# Altium schematic sheet SCH_Mauri_2_Octave_Bass_Boost.SchDoc(SCH_Mauri_2_Octave_Bass_Boost)
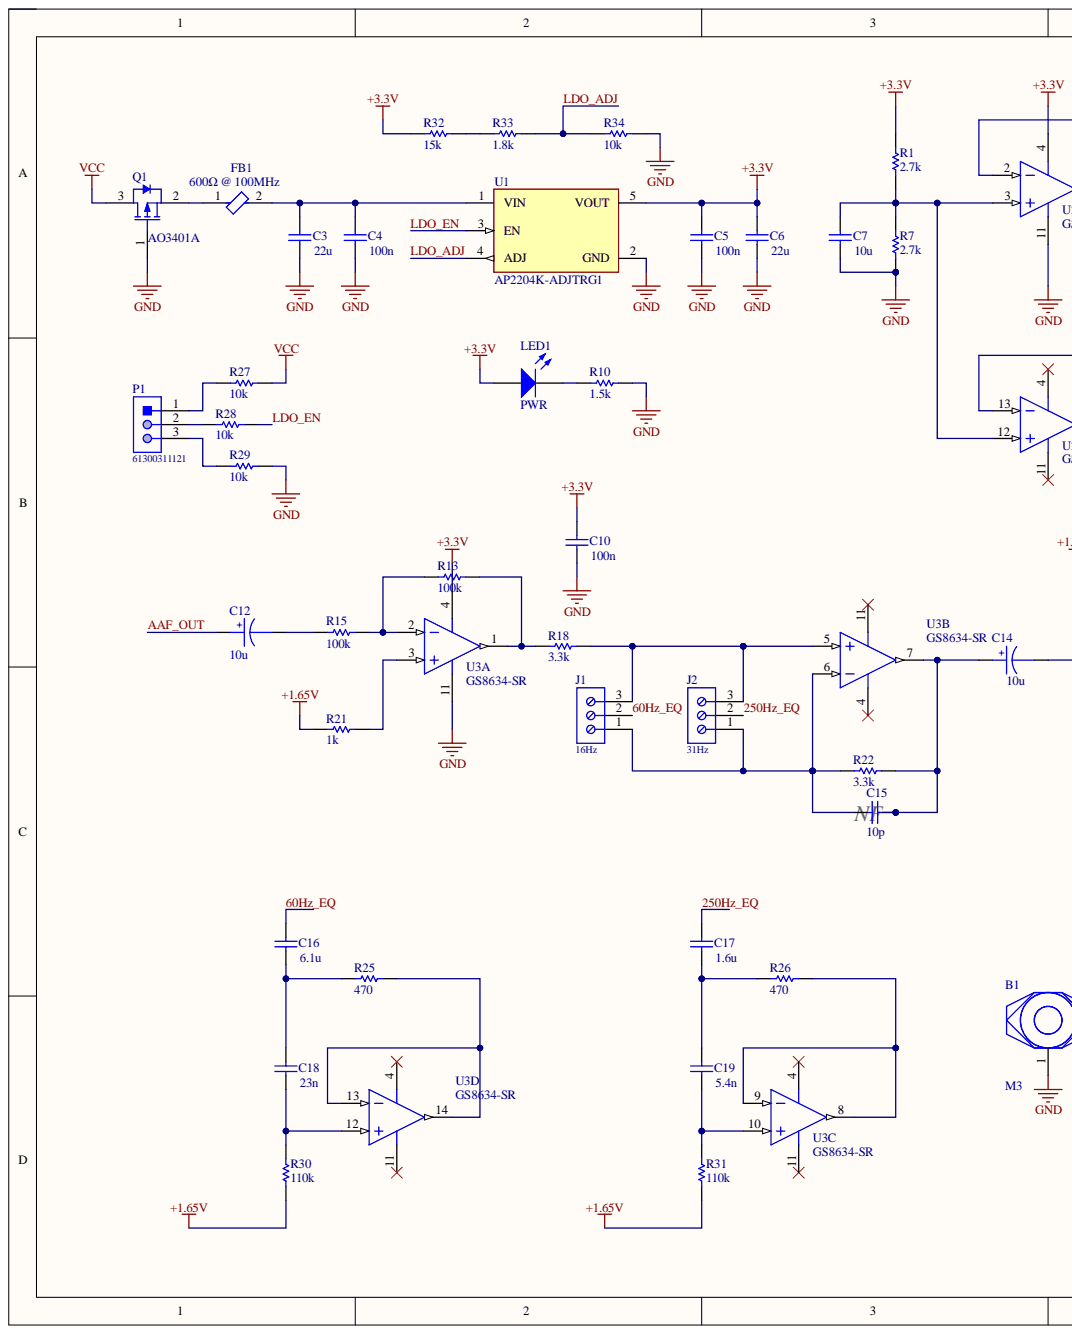
[diagram p0: SCH_Mauri_2_Octave_Bass_Boost.SchDoc, left]
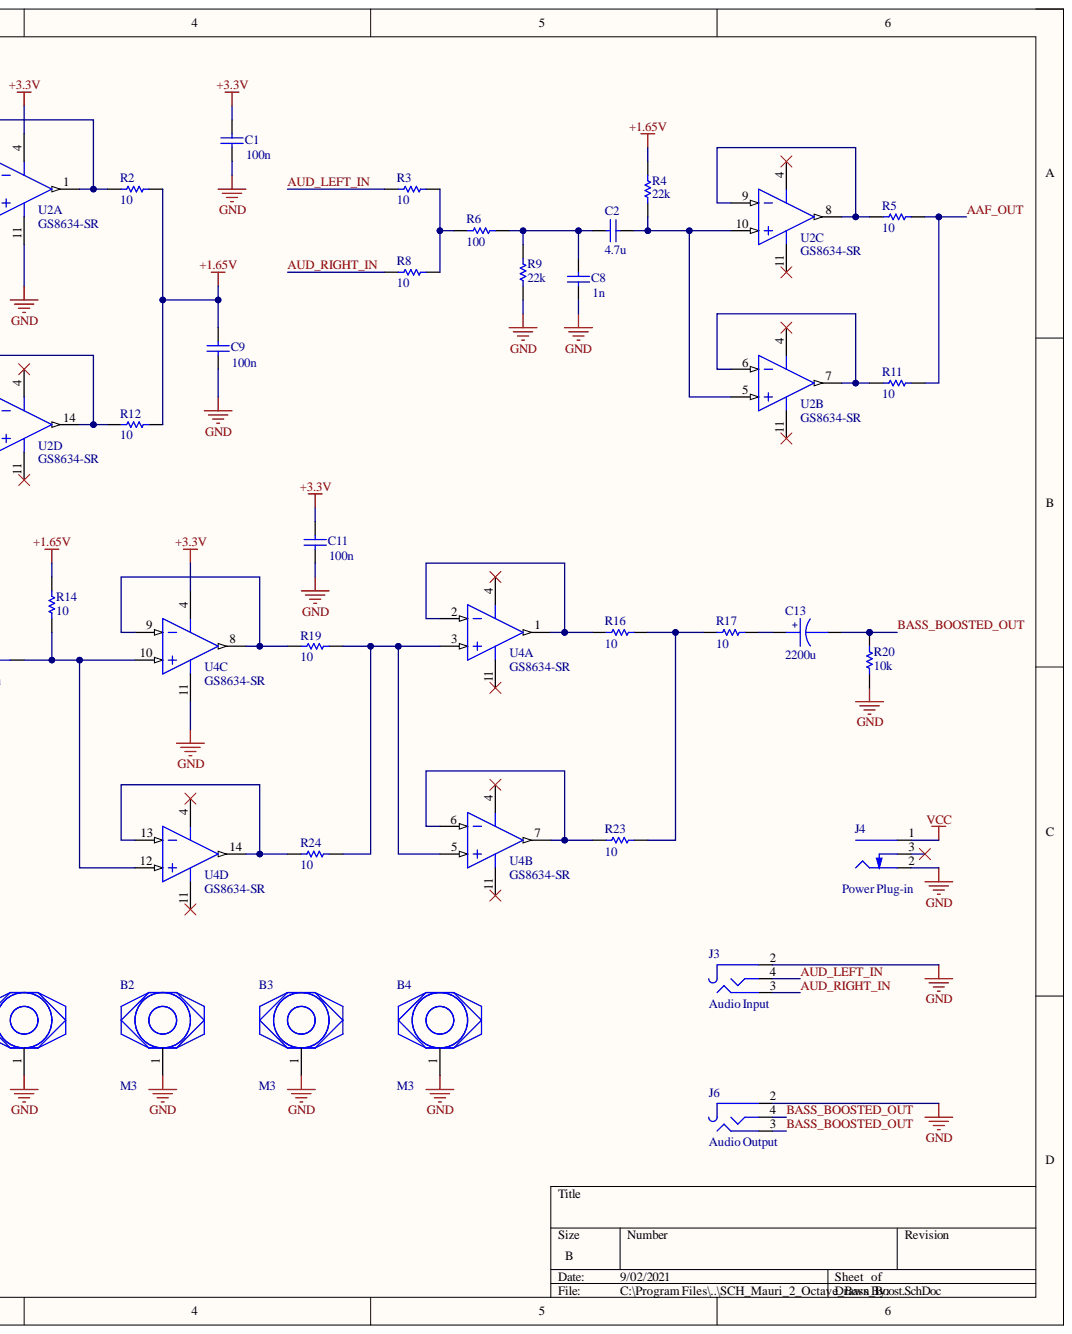
[diagram p1: SCH_Mauri_2_Octave_Bass_Boost.SchDoc, right]

## Parts
B1  M3  [BOLTM3 - with vias]
B2  M3  [BOLTM3 - with vias]
B3  M3  [BOLTM3 - with vias]
B4  M3  [BOLTM3 - with vias]
C1  100n  [CAPC2013X95X50NL10T25]
C2  4.7u  [CAPC2013X95X50NL10T25]
C3  22u  [CAPC2013X95X50NL10T25]
C4  100n  [CAPC2013X95X50NL10T25]
C5  100n  [CAPC2013X95X50NL10T25]
C6  22u  [CAPC2013X95X50NL10T25]
C7  10u  [CAPC2013X95X50NL10T25]
C8  1n  [CAPC2013X95X50NL10T25]
C9  100n  [CAPC2013X95X50NL10T25]
C10  100n  [CAPC2013X95X50NL10T25]
C11  100n  [CAPC2013X95X50NL10T25]
C12  10u  [RB.1/.2/.4]
C13  2200u  [RB.1/.2/.4]
C14  10u  [RB.1/.2/.4]
C15  10p  [CAPC2013X95X50NL10T25]
C16  6.1u  [CAPC2013X95X50NL10T25]
C17  1.6u  [CAPC2013X95X50NL10T25]
C18  23n  [CAPC2013X95X50NL10T25]
C19  5.4n  [CAPC2013X95X50NL10T25]
FB1  value 600Ω @ 100MHz  Impedance At Frequency: 600Ω @ 100MHz  Test Frequency: 100MHz  [FP-ILHB-0805-IPC_A]  (hidden comment: GZ2012D601TF)
J1  16Hz  [691213710003]
J2  31Hz  [691213710003]
J3  Audio Input  [AUDIO-TH_PJ313D]
J4  Power Plug-in  [CUI PJ-102AH]
J6  Audio Output  [AUDIO-TH_PJ313D]
LED1  PWR  [0805_A]
P1  61300311121  [61300311121]
Q1  AO3401A  [AO-SOT-23-3L_V]
R1  2.7k  [RESC2013X70X40NL20T20]
R2  10  [RESC2013X70X40NL20T20]
R3  10  [RESC2013X70X40NL20T20]
R4  22k  [RESC2013X70X40NL20T20]
R5  10  [RESC2013X70X40NL20T20]
R6  100  [RESC2013X70X40NL20T20]
R7  2.7k  [RESC2013X70X40NL20T20]
R8  10  [RESC2013X70X40NL20T20]
R9  22k  [RESC2013X70X40NL20T20]
R10  1.5k  [RESC2013X70X40NL20T20]
R11  10  [RESC2013X70X40NL20T20]
R12  10  [RESC2013X70X40NL20T20]
R13  100k  [RESC2013X70X40NL20T20]
R14  10  [RESC2013X70X40NL20T20]
R15  100k  [RESC2013X70X40NL20T20]
R16  10  [RESC2013X70X40NL20T20]
R17  10  [RESC2013X70X40NL20T20]
R18  3.3k  [RESC2013X70X40NL20T20]
R19  10  [RESC2013X70X40NL20T20]
R20  10k  [RESC2013X70X40NL20T20]
R21  1k  [RESC2013X70X40NL20T20]
R22  3.3k  [RESC2013X70X40NL20T20]
R23  10  [RESC2013X70X40NL20T20]
R24  10  [RESC2013X70X40NL20T20]
R25  470  [RESC2013X70X40NL20T20]
R26  470  [RESC2013X70X40NL20T20]
R27  10k  [RESC2013X70X40NL20T20]
R28  10k  [RESC2013X70X40NL20T20]
R29  10k  [RESC2013X70X40NL20T20]
R30  110k  [RESC2013X70X40NL20T20]
R31  110k  [RESC2013X70X40NL20T20]
R32  15k  [RESC2013X70X40NL20T20]
R33  1.8k  [RESC2013X70X40NL20T20]
R34  10k  [RESC2013X70X40NL20T20]
U1  AP2204K-ADJTRG1  [FP-SOT25-MFG]
U2  GS8634-SR  [D0014A_V]  (parts A,B,C,D)
U3  GS8634-SR  [D0014A_V]  (parts A,B,C,D)
U4  GS8634-SR  [D0014A_V]  (parts A,B,C,D)

## Nets
+1.65V: C9.2 R2.2 R4.1 R12.2 R14.2 R21.1 R30.1 R31.1
+3.3V: C1.2 C5.2 C6.2 C10.2 C11.2 LED1.2 R1.1 R32.1 U1.5(VOUT) U2.4 U3.4 U4.4
GND: B1.1 B2.1 B3.1 B4.1 C1.1 C3.1 C4.1 C5.1 C6.1 C7.1 C8.1 C9.1 C10.1 C11.1 J3.2 J4.2 J6.2 Q1.1 R7.2 R9.2 R10.2 R20.1 R29.1 R34.2 U1.2(GND) U2.11 U3.11 U4.11
VCC: J4.1 Q1.3 R27.1
60Hz_EQ: C16.2 J1.2
250Hz_EQ: C17.2 J2.2
AAF_OUT: C12.1 R5.2 R11.2
AUD_LEFT_IN: J3.4 R3.2
AUD_RIGHT_IN: J3.3 R8.2
BASS_BOOSTED_OUT: C13.2 J6.3 J6.4 R20.2
LDO_ADJ: R33.2 R34.1 U1.4(ADJ)
LDO_EN: R28.1 U1.3(EN)
NetC2_1: C2.1 R4.2 U2.5 U2.10
NetC2_2: C2.2 C8.2 R6.1 R9.1
NetC3_2: C3.2 C4.2 FB1.2 U1.1(VIN)
NetC7_2: C7.2 R1.2 R7.1 U2.3 U2.12
NetC12_2: C12.2 R15.1
NetC13_1: C13.1 R17.1
NetC14_1: C14.1 C15.1 R22.2 U3.7
NetC14_2: C14.2 R14.1 U4.10 U4.12
NetC15_2: C15.2 J1.1 J2.1 R22.1 U3.6
NetC16_1: C16.1 C18.2 R25.2
NetC17_1: C17.1 C19.2 R26.2
NetC18_1: C18.1 R30.2 U3.12
NetC19_1: C19.1 R31.2 U3.10
NetFB1_1: FB1.1 Q1.2
NetJ1_3: J1.3 J2.3 R18.2 U3.5
NetLED1_1: LED1.1 R10.1
NetP1_1: P1.1 R27.2
NetP1_2: P1.2 R28.2
NetP1_3: P1.3 R29.2
NetR2_1: R2.1 U2.1 U2.2
NetR3_1: R3.1 R6.2 R8.1
NetR5_1: R5.1 U2.8 U2.9
NetR11_1: R11.1 U2.6 U2.7
NetR12_1: R12.1 U2.13 U2.14
NetR13_1: R13.1 R15.2 U3.2
NetR13_2: R13.2 R18.1 U3.1
NetR16_1: R16.1 R17.2 R23.1
NetR16_2: R16.2 U4.1 U4.2
NetR19_1: R19.1 U4.8 U4.9
NetR19_2: R19.2 R24.2 U4.3 U4.5
NetR21_2: R21.2 U3.3
NetR23_2: R23.2 U4.6 U4.7
NetR24_1: R24.1 U4.13 U4.14
NetR25_1: R25.1 U3.13 U3.14
NetR26_1: R26.1 U3.8 U3.9
NetR32_2: R32.2 R33.1

Not connected: J4 3

## Library data of the parts
B1-B4 = BOLT: M3 Mounting Bolt; Component Kind: Standard; Library Name: Altium Primer.SchLib
C1-C11 C15-C19 = CMP-001-00018-6: Capacitor Type: Ceramic; Tolerance: 10%; Value: 100nF; Voltage Rating: 50V; Case/Package: 0805; Component Kind: Standard; Max Operating Temperature: 125°C; Min Operating Temperature: -55°C; Mounting Technology: SM; Pins: 2; RoHS Compliant: True; Library Name: Minh Man Ly's Workspace
C12-C14 = CAPACITOR POL: Polarized Cap; Component Kind: Standard; Library Name: Altium Primer.SchLib
FB1 = CMP-02428-000001-1: FERRITE BEAD 600 OHM 0805 1LN; Manufacturer: Vishay; Manufacturer Part Number: ILHB0805ER601V; Rms Current: 2000mA; Series Resistance: 0.1Ω; Shielding: true; Tolerance: 25%; Automotive: No; Category: FBead; Component Kind: Standard; ComponentLink2Description: Datasheet; ComponentLink2URL: http://www.vishay.com/docs/34102/ilhb.pdf; Device Class L1: Passive Components; Device Class L2: EMI / RFI Components; Device Class L3: Ferrite Beads and Chips; Digikey Description: FERRITE BEAD 600 OHM 0805 1LN; Height: 1.1mm; Ipc Land Pattern Name: CAPC200125X90; LCSC Part #: C1017; Lead Free: Yes; Library Name: Altium Content Vault; Mouser Description: Ferrite Beads Multi-Layer High Current 600Ohm 25% 100MHz 2A 100mOhm DCR 0805 T/R; Octopart: https://octopart.com/ilhb0805er601v-vishay-39650184; Package: ILHB-0805; RoHS: TRUE; Temperature: -55°C to +125°C; Temperature Range High: +125°C; Temperature Range Low: -55°C
J1 J2 = CMP-1502-02527-1: WJ126V-5.0-3P. P=5.0mm Screw terminal RoHS; Manufacturer: Ningbo Kangnex Elec; Pin Number: 3; Pitch: 5.00mm; Component Kind: Standard; Datasheet URL: https://lcsc.com/product-detail/Pluggable-System-Terminal-Block_Ningbo-Kangnex-Elec-WJ126V-5-0-3P_C8401.html; LCSC part #: C8401; Library Name: Altium Content Vault; Manufacturer URL: https://lcsc.com/product-detail/Pluggable-System-Terminal-Block_Ningbo-Kangnex-Elec-WJ126V-5-0-3P_C8401.html; Mounting Technology: THT; Operating Temperature: -40to105degC; Package Reference: 691213710003; Packaging: Box; Part Number: 691213710003; Value: P = 5.0mm; Publisher: WurthElektronik
J3 J6 = 3.5mm Plug-in headphone holder 5: Component Kind: Standard; LCSC Part #: C18167; Library Name: SCH_LIB1.SchLib
J4 = PJ-102AH: CONN PWR JACK 2X5.5MM SOLDER; Manufacturer: CUI Devices; Actual Diameter: 0.079" (2.00mm ID), 0.256" (6.50mm OD); Current Rating (Amps): 5A; Database Table Name: Connectors - Barrel - Power; Gender: Male; Industry Recognized Mating Diameter: 2.00mm ID (0.079"), 5.50mm OD (0.217"); Internal Switch(s): Single Switch, Normally Closed; Material Flammability Rating: UL94 V-0; Mating Length/Depth: 0.354" (9.00mm); Number of Positions/Contacts: 2 Conductors, 3 Contacts; Shielding: Unshielded; Voltage - Rated: 24VDC; Component Kind: Standard; ComponentLink1Description: Datasheet; ComponentLink1URL: https://www.cuidevices.com/product/resource/digikeypdf/pj-102ah.pdf; ComponentLink2Description: DigiKey Link; ComponentLink2URL: //digikey.com/product-detail/en/cui-devices/PJ-102AH/CP-102AH-ND/408448; Connector Type: Jack; LastUpdated: 12/16/2019 7:01:27 PM; Library Name: Celestial Altium Library - altium_library.DbLib; Lifecycle Status: 0; Minimum Order: 1; Mounting Type: Through Hole, Right Angle; Packaging: Tray; Part Number: PJ-102AH; Part Status: Active; Price: 0.76; Series: -; Supplier 1: DigiKey; Supplier Part Number 1: CP-102AH-ND; Termination: Solder
LED1 = CMP-1426-00010-1: Vf = 2.7V -> 3.2V; Manufacturer: XINGLIGHT; PartNumber: XL-2012UGC; Color: Green; Component Kind: Standard; ComponentLink1Description: Manufacturer URL; ComponentLink1URL: http://www.we-online.com; ComponentLink2Description: Datasheet; ComponentLink2URL: http://katalog.we-online.com/led/datasheet/150080SS75000.pdf; LCSC Part #: C965815; Library Name: Altium Content Vault; PackageReference: 0805_A
P1 = CMP-1502-01062-1: THT Vertical Pin Header WR-PHD, Pitch 2.54 mm, Single Row, 3 pins; Manufacturer: Wurth Elektronik; Contact Resistance: 20mOhm; Gender: Male; Pin Number: 3; Pitch: 2.54mm; Rated Current: 3A; Withstanding Voltage: 500VAC; Working Voltage: 250VAC; Component Kind: Standard; Datasheet URL: https://katalog.we-online.de/em/datasheet/6130xx11121.pdf; Library Name: Altium Content Vault; Manufacturer URL: http://www.we-online.de; Mounting Technology: THT; Operating Temperature: -40to105degC; Orientation: Vertical; Package Reference: 61300311121; Packaging: Bag; Part Number: 61300311121; Row Number: Single; Publisher: WurthElektronik
Q1 = CMP-2000-05778-1: P-Channel -30V 4.2A 1.5V @ 250uA 65mΩ @ 3A,10V 1W SOT-23 MOSFETs RoHS; Manufacturer: Alpha and Omega; Channel Mode: Enhancement; Continuous Drain Current: 4A; Drain Efficiency: NotRequired%; Drain-Source On-Res: 0.044ohm; Drain-Source On-Volt: 30V; Frequency (Max): NotRequiredMHz; Gate-Source Voltage (Max): ?12V; Noise Figure: NotRequireddB; Number of  Elements: 1; Output Power (Max): NotRequiredW; Polarity: P; Power Dissipation: 1.4W; Rad Hardened: No; Type: PowerMOSFET; Component Kind: Standard; Datasheet URL: http://www.aosmd.com/res/data_sheets/AO3401A.pdf; Datasheet Version: Rev. 3, 03/2011; Drain Current (Max): 4A; LCSC Part #: C700954; Library Name: Altium Content Vault; Manufacturer URL: http://www.aosmd.com/; Mounting: SurfaceMount; Mounting Technology: SurfaceMount; Operating Temp Range: -55Cto150C; Operating Temperature Classification: Military; Package Description: 3-Pin Small Outline Transistor, Body 2.95 x 1.6 mm, Pitch 0.95 mm; Package Reference: SOT-23-3L; Package Type: SOT-23; Package URL: http://www.aosmd.com/res/packaging_information/SOT-23-3L.pdf; Pin Count: 3; Power Gain: NotRequireddB
R1-R20 R22-R34 = CMP-009-00010-5: Power: 125mW; Tolerance: 1%; Value: 1k; Voltage Rating: 150V; Case/Package: 0805; Component Kind: Standard; Max Operating Temperature: 155°C; Min Operating Temperature: -55°C; Mounting Technology: SM; Pins: 2; RoHS Compliant: True; Library Name: Minh Man Ly's Workspace
R21 = CMP-009-00010-5: Power: 125mW; Tolerance: 1%; Voltage Rating: 150V; Case/Package: 0805; Component Kind: Standard; Max Operating Temperature: 155°C; Min Operating Temperature: -55°C; Mounting Technology: SM; Pins: 2; RoHS Compliant: True; Value: 1k; Library Name: Minh Man Ly's Workspace
U1 = CMP-2000-05784-3: IC REG LIN POS ADJ 150MA SOT23-5; Dropout Voltage: 360mV; Max Input Voltage: 24V; Max Output Current: 250mA; Min Input Voltage: 2.3V; Number of Outputs: 1; Output Type: Adjustable; Output Voltage: 22V; Polarity: Positive; Power Supply Rejection Ratio (PSRR): 60dB; Quiescent Current: 50uA; Reference Voltage: 1.24V; Case/Package: SOT-23-5; Component Kind: Standard; Height: 1.3mm; Lead Free: Lead Free; Length: 3.02mm; Library Name: Altium Content Vault; Max Operating Temperature: 85°C; Min Operating Temperature: -40°C; Mount: Surface Mount; Number of Pins: 5; Number of Regulators: 1; Output Current: 150mA; Package Quantity: 3000; Packaging: Tape and Reel; Radiation Hardening: No; Weight: 0.001058oz; Width: 1.7mm
U2-U4 = CMP-1685-00010-1: Quad Low-Voltage Rail-to-Rail Output Operational Amplifier, 2.7 to 5.5 V, -40 to 125 degC, 14-Pin SOIC (D), Green (RoHS & no Sb/Br), Tape and Reel; Code_JEDEC: MS-012; Component Kind: Standard; ComponentLink1Description: Manufacturer URL; ComponentLink1URL: http://www.ti.com/; ComponentLink2Description: Datasheet; ComponentLink2URL: http://www.ti.com/lit/ds/symlink/lmv324.pdf; ComponentLink3Description: Package Specification; ComponentLink3URL: http://www.ti.com/lit/ml/mpds177g/mpds177g.pdf; DatasheetVersion: SLOS263W, 10/2014; Library Name: Altium Content Vault; PackageDescription: 14-Pin Small Outline Integrated Circuit, Body 8.65 x 3.9 mm, Pitch 1.27 mm; PackageReference: D0014A
